# Altium schematic sheet PySpirit.SchDoc
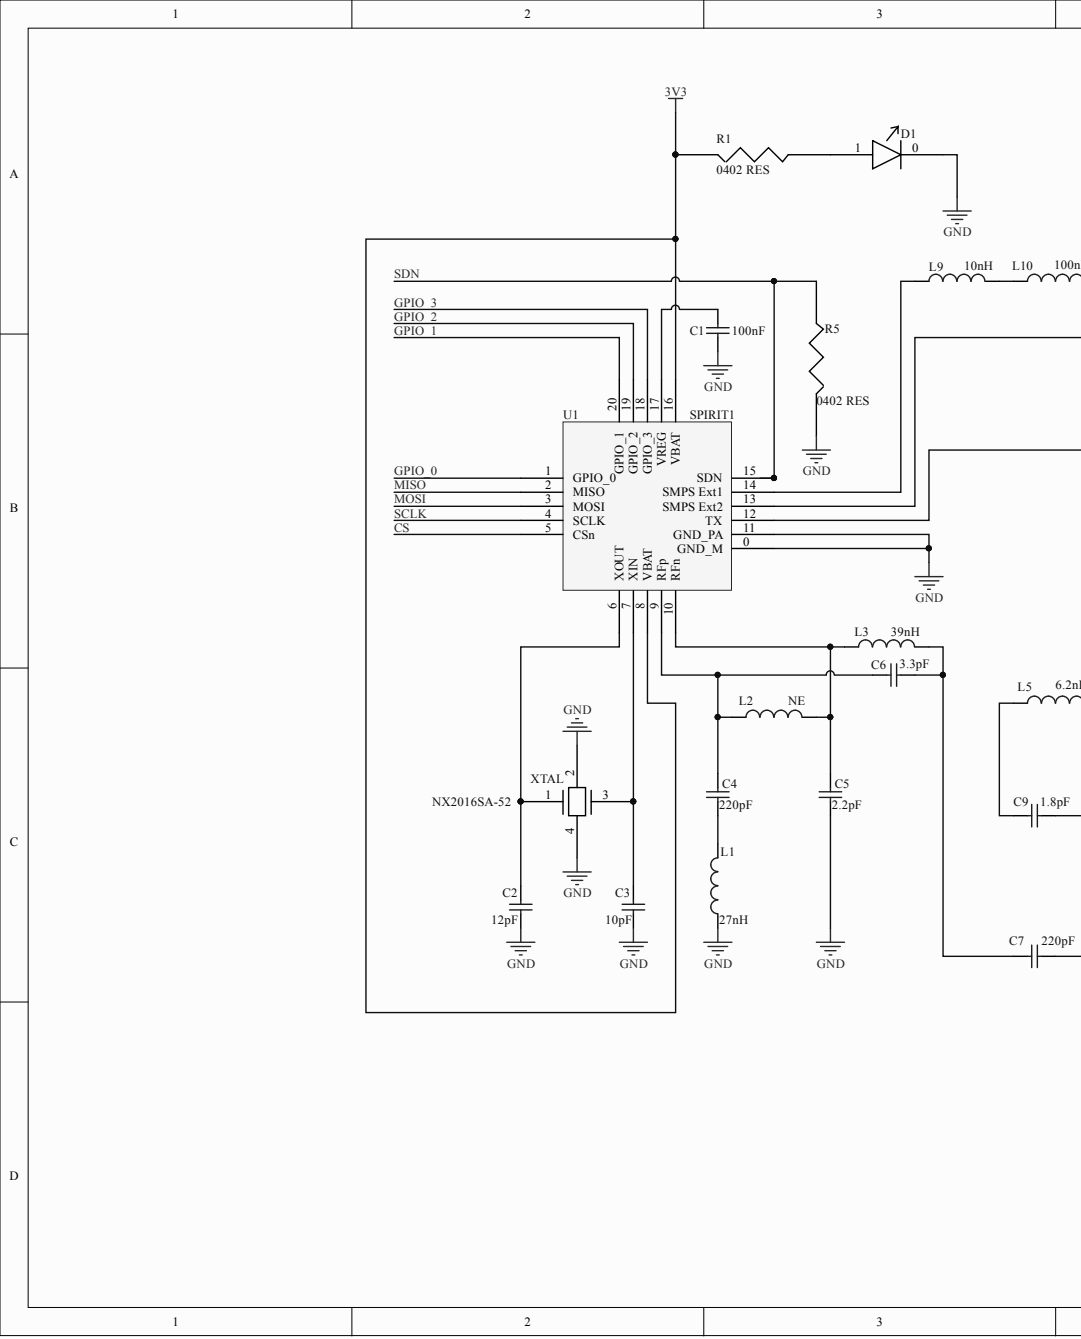
[diagram p0: PySpirit.SchDoc, left]
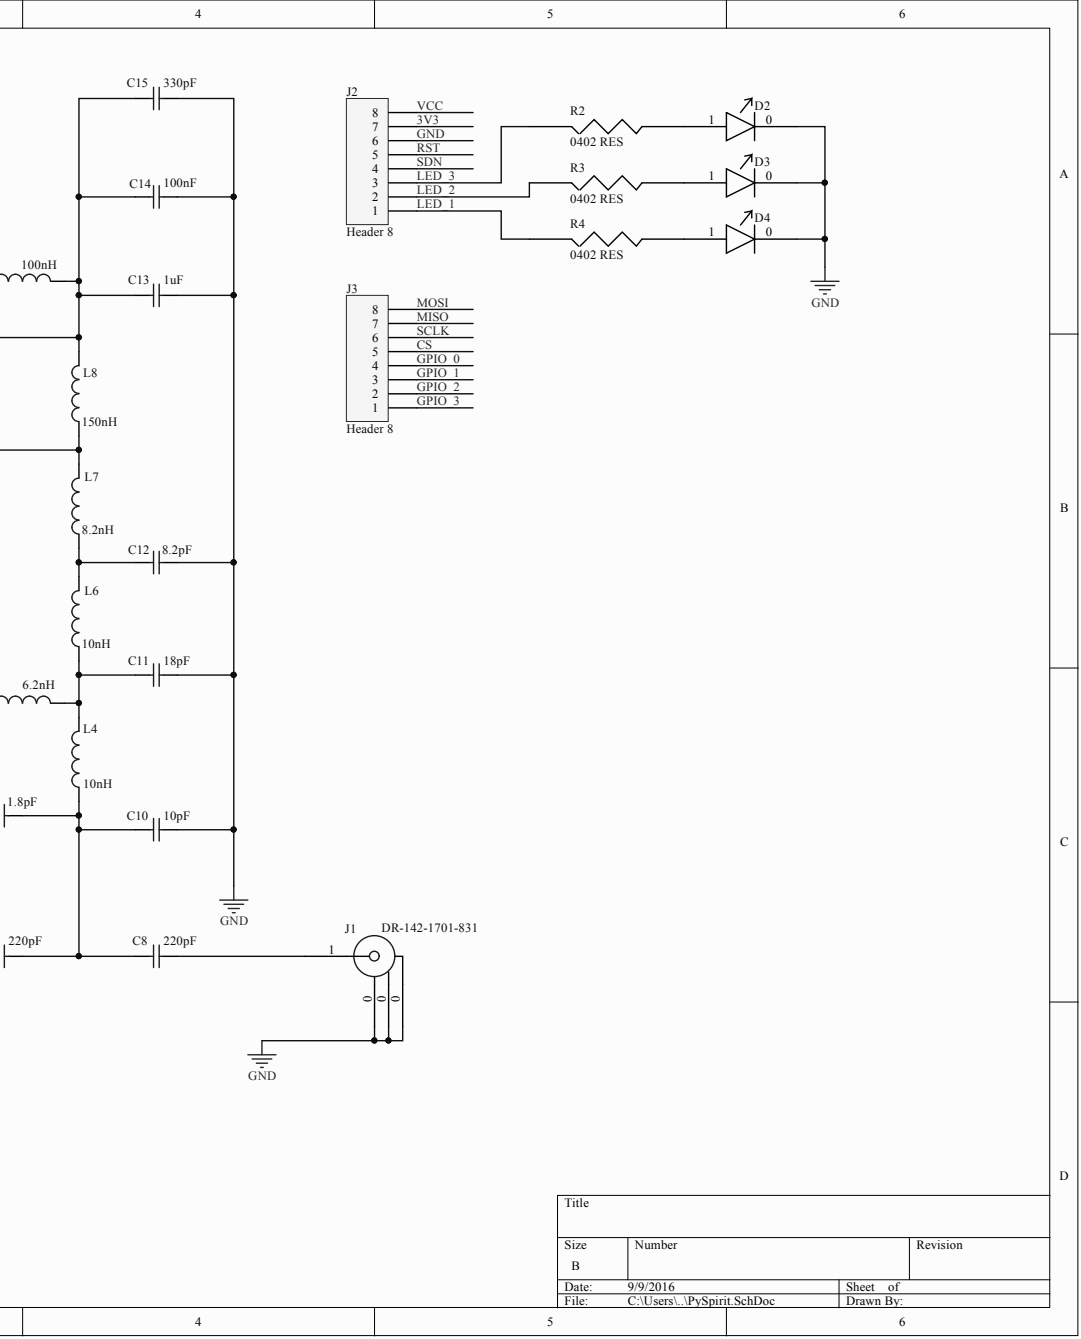
[diagram p1: PySpirit.SchDoc, right]

## Parts
C1  value 100nF  [1608[0603]]  (hidden comment: Cap Semi)
C2  value 12pF  [0402]  (hidden comment: Cap Semi)
C3  value 10pF  [0402]  (hidden comment: Cap Semi)
C4  value 220pF  [0402]  (hidden comment: Cap Semi)
C5  value 2.2pF  [0402]  (hidden comment: Cap Semi)
C6  value 3.3pF  [0402]  (hidden comment: Cap Semi)
C7  value 220pF  [0402]  (hidden comment: Cap Semi)
C8  value 220pF  [0402]  (hidden comment: Cap Semi)
C9  value 1.8pF  [0402]  (hidden comment: Cap Semi)
C10  value 10pF  [0402]  (hidden comment: Cap Semi)
C11  value 18pF  [0402]  (hidden comment: Cap Semi)
C12  value 8.2pF  [0402]  (hidden comment: Cap Semi)
C13  value 1uF  [0402]  (hidden comment: Cap Semi)
C14  value 100nF  [1608[0603]]  (hidden comment: Cap Semi)
C15  value 330pF  [0402]  (hidden comment: Cap Semi)
D1  0402 LED  [0402 LED]  (value not drawn)
D2  0402 LED  [0402 LED]  (value not drawn)
D3  0402 LED  [0402 LED]  (value not drawn)
D4  0402 LED  [0402 LED]  (value not drawn)
J1  DR-142-1701-831  [DR-142-1701-831]
J2  Header 8  [HDR1X8]
J3  Header 8  [HDR1X8]
L1  value 27nH  [0402-A]  (hidden comment: Inductor)
L2  value NE  [0402-A]  (hidden comment: Inductor)
L3  value 39nH  [0402-A]  (hidden comment: Inductor)
L4  value 10nH  [0402-A]  (hidden comment: Inductor)
L5  value 6.2nH  [0402-A]  (hidden comment: Inductor)
L6  value 10nH  [0402-A]  (hidden comment: Inductor)
L7  value 8.2nH  [0402-A]  (hidden comment: Inductor)
L8  value 150nH  [0402-A]  (hidden comment: Inductor)
L9  value 10nH  [J1-0603]  (hidden comment: Inductor)
L10  value 100nH  [0402-A]  (hidden comment: Inductor)
R1  0402 RES  [0402 RES]
R2  0402 RES  [0402 RES]
R3  0402 RES  [0402 RES]
R4  0402 RES  [0402 RES]
R5  0402 RES  [0402 RES]
U1  SPIRIT1  [SPIRIT1]
XTAL  NX2016SA-52  [NX2016SA-52]

## Nets
3V3: J2.7 R1.0 U1.8(VBAT) U1.16(VBAT)
CS: J3.5 U1.5(CSn)
GND: C1.1 C2.1 C3.1 C5.1 C10.2 C11.2 C12.2 C13.2 C14.2 C15.2 D1.0 D2.0 D3.0 D4.0 J1.0 J2.6 L1.1 R5.0 U1.0(GND_M) U1.11(GND_PA) XTAL.2 XTAL.4
GPIO_0: J3.4 U1.1(GPIO_0)
GPIO_1: J3.3 U1.20(GPIO_1)
GPIO_2: J3.2 U1.19(GPIO_2)
GPIO_3: J3.1 U1.18(GPIO_3)
LED_1: J2.1 R4.0
LED_2: J2.2 R3.0
LED_3: J2.3 R2.0
MISO: J3.7 U1.2(MISO)
MOSI: J3.8 U1.3(MOSI)
RST: J2.5
SCLK: J3.6 U1.4(SCLK)
SDN: J2.4 R5.1 U1.15(SDN)
VCC: J2.8
NetC1_2: C1.2 U1.17(VREG)
NetC2_2: C2.2 U1.6(XOUT) XTAL.1
NetC3_2: C3.2 U1.7(XIN) XTAL.3
NetC4_1: C4.1 L1.2
NetC4_2: C4.2 C6.1 L2.1 U1.9(RFp)
NetC5_2: C5.2 L2.2 L3.1 U1.10(RFn)
NetC6_2: C6.2 C7.1 L3.2
NetC7_2: C7.2 C8.1 C9.2 C10.1 L4.1
NetC8_2: C8.2 J1.1
NetC9_1: C9.1 L5.1
NetC11_1: C11.1 L4.2 L5.2 L6.1
NetC12_1: C12.1 L6.2 L7.1
NetC13_1: C13.1 C14.1 C15.1 L8.2 L10.2 U1.13(SMPS_Ext2)
NetD1_1: D1.1 R1.1
NetD2_1: D2.1 R2.1
NetD3_1: D3.1 R3.1
NetD4_1: D4.1 R4.1
NetL7_2: L7.2 L8.1 U1.12(TX)
NetL9_1: L9.1 U1.14(SMPS_Ext1)
NetL9_2: L9.2 L10.1

## Library data of the parts
C1-C15 = Cap Semi: Capacitor (Semiconductor SIM Model); Component Kind: Standard; LatestRevisionDate: 29-May-2009; LatestRevisionNote: IPC-7351 Footprint Added.; Library Name: Miscellaneous Devices.IntLib; PackageDescription: Chip Capacitor; PackageReference: C1206; Published: 8-Jun-2000; Simulation: CAP; Publisher: Altium Limited
J2 J3 = Header 8: Header, 8-Pin; Component Kind: Standard; LatestRevisionDate: 17-Jul-2002; LatestRevisionNote: Re-released for DXP Platform.; Library Name: Miscellaneous Connectors.IntLib; Publisher: Altium Limited
L1-L10 = Inductor: Code_IEC: 1005; Component Kind: Standard; Description: Inductor; LatestRevisionDate: 29-May-2009; LatestRevisionNote: IPC-7351 Footprint Added.; Library Name: Miscellaneous Devices.IntLib; PackageDescription: Chip Inductor; PackageReference: 0402-A; Published: 8-Jun-2000; Simulation: INDUCTOR; Publisher: Altium Limited
  L1-L8 L10: Code_JEITA: 0402
  L9: Code_JEITA: 0603
U1 = SPIRIT1: Manufacturer: STMicroelectronics; Manufacturer Part Number: SPIRIT1QTR; Current - Receiving: 9.2mA ~ 9.8mA; Current - Transmitting: 6mA ~ 54mA; Data Rate (Max): 500kbps; Frequency: 150MHz ~ 174MHz, 300MHz ~ 348MHz, 387MHz ~ 470MHz, 779MHz ~ 956MHz; GPIO: 4; Modulation: 2FSK, ASK, GFSK, GMSK, MSK, OOK; Power - Output: 16dBm; RF Family/Standard: General ISM < 1GHz; Sensitivity: -118dBm; Serial Interfaces: SPI; Voltage - Supply: 1.8 V ~ 3.6 V; Category: RF/IF and RFID; Component Kind: Standard; ComponentLink1Description: http://www.st.com/content/ccc/resource/technical/document/datasheet/68/6c/7b/ec/b2/6b/49/16/DM00047607.pdf/files/DM00047607.pdf/jcr:content/translations/en.DM00047607.pdf; ComponentLink1URL: http://www.st.com/content/ccc/resource/technical/document/datasheet/68/6c/7b/ec/b2/6b/49/16/DM00047607.pdf/files/DM00047607.pdf/jcr:content/translations/en.DM00047607.pdf; Library Name: SpiritLib.SchLib; Memory Size: -; Operating Temperature: -40°C ~ 105°C; Package / Case: 20-VFQFN Exposed Pad; Packaging: Tape & Reel (TR); Pricing 1: 4500=1.27575, 9000=1.2285, 13500=1.18125, 31500=1.15763, 112500=1.134 (USD); Protocol: -; RoHS: RoHS Compliant; Stock 1: 0; Supplier 1: Digi-Key; Supplier Part Number 1: 497-13383-2-ND; Type: TxRx + MCU
D1-D4 = 0402 LED: Component Kind: Standard; Library Name: SpiritLib.SchLib
J1 = DR-142-1701-831: Component Kind: Standard; Library Name: SpiritLib.SchLib
R1-R5 = 0402 RES: Component Kind: Standard; Library Name: SpiritLib.SchLib
XTAL = NX2016SA-52: Component Kind: Standard; Library Name: SpiritLib.SchLib
